# Altium schematic sheet Analog2.SchDoc
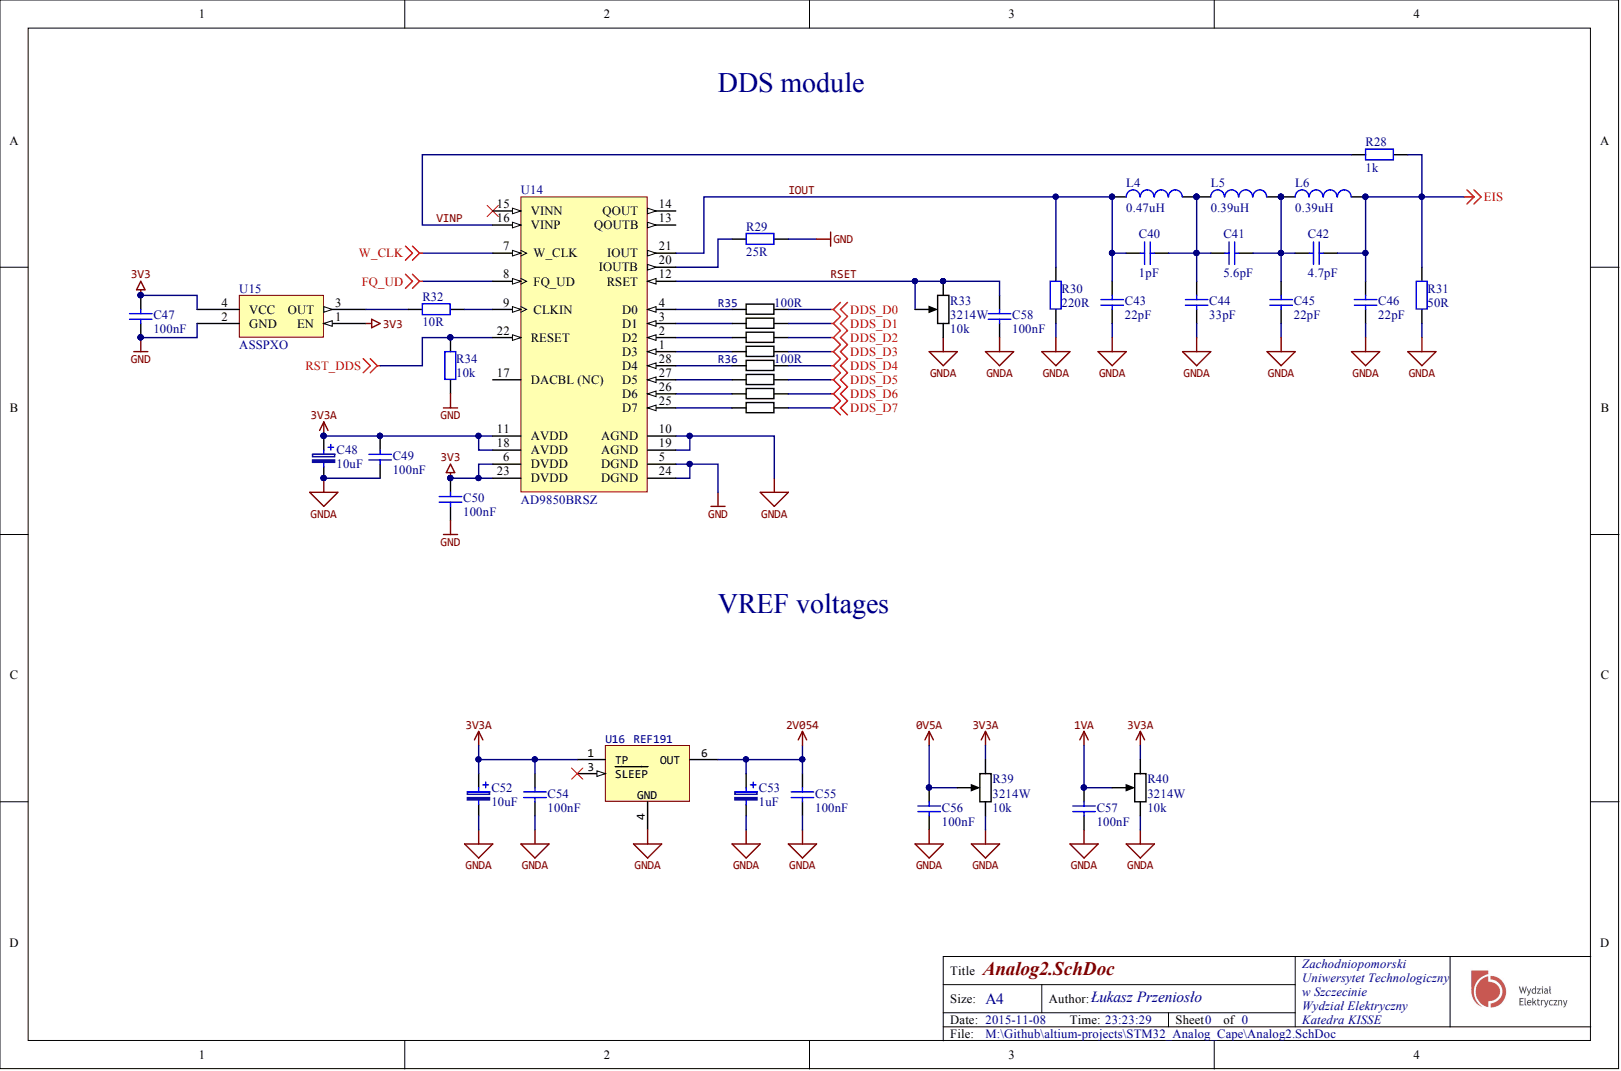
[diagram p0: Analog2.SchDoc]

## Parts
C40  1pF  [CAPC1608N]
C41  5.6pF  [CAPC1608N]
C42  4.7pF  [CAPC1608N]
C43  22pF  [CAPC1608N]
C44  33pF  [CAPC1608N]
C45  22pF  [CAPC1608N]
C46  22pF  [CAPC1608N]
C47  100nF  [CAPC1608N]
C48  10uF  [SMDA]
C49  100nF  [CAPC1608N]
C50  100nF  [CAPC1608N]
C52  10uF  [SMDA]
C53  1uF  [SMDA]
C54  100nF  [CAPC1608N]
C55  100nF  [CAPC1608N]
C56  100nF  [CAPC1608N]
C57  100nF  [CAPC1608N]
C58  100nF  [CAPC1608N]
L4  value 0.47uH  [inductor_0603]  (hidden comment: Ferrite)
L5  value 0.39uH  [inductor_0603]  (hidden comment: Ferrite)
L6  value 0.39uH  [inductor_0603]  (hidden comment: Ferrite)
R28  1k  [RESC1608N]
R29  25R  [RESC1608N]
R30  220R  [RESC1608N]
R31  50R  [RESC1608N]
R32  10R  [RESC1608N]
R33  3214W  value 10k  [POT3214W]
R34  10k  [RESC1608N]
R35  value 100R  [RES_ARRAY_4x0603]  (hidden comment: RES_ARRAY_4)
R36  value 100R  [RES_ARRAY_4x0603]  (hidden comment: RES_ARRAY_4)
R39  3214W  value 10k  [POT3214W]
R40  3214W  value 10k  [POT3214W]
U14  AD9850BRSZ  [SSOP28]
U15  ASSPXO  [EPON_XO]
U16  REF191  [SO-8_L]

## Nets
0V5A: C56.1 R39.2
1VA: C57.1 R40.2
2V054: C53.1 C55.1 U16.6(OUT)
3V3: C47.1 C50.1 U14.6(DVDD) U14.23(DVDD) U15.1(EN) U15.4(VCC)
3V3A: C48.1 C49.1 C52.1 C54.1 R39.3 R40.1 U14.11(AVDD) U14.18(AVDD) U16.1(TP)
DDS_D0: R35.7
DDS_D1: R35.5
DDS_D2: R35.3
DDS_D3: R35.1
DDS_D4: R36.7
DDS_D5: R36.5
DDS_D6: R36.3
DDS_D7: R36.1
EIS: C42.2 C46.2 L6.2 R28.2 R31.1
FQ_UD: U14.8(FQ_UD)
GND: C47.2 C50.2 R29.1 R34.2 U14.5(DGND) U14.24(DGND) U15.2(GND)
GNDA: C43.1 C44.1 C45.1 C46.1 C48.2 C49.2 C52.2 C53.2 C54.2 C55.2 C56.2 C57.2 C58.1 R30.2 R31.2 R33.3 R39.1 R40.3 U14.10(AGND) U14.19(AGND) U16.4
RST_DDS: R34.1 U14.22(RESET)
W_CLK: U14.7(W_CLK)
IOUT: C40.1 C43.2 L4.1 R30.1 U14.21(IOUT)
RSET: C58.2 R33.1 R33.2 U14.12(RSET)
VINP: R28.1 U14.16(VINP)
NetC40_2: C40.2 C41.1 C44.2 L4.2 L5.1
NetC41_2: C41.2 C42.1 C45.2 L5.2 L6.1
NetR29_2: R29.2 U14.20(IOUTB)
NetR32_1: R32.1 U14.9(CLKIN)
NetR32_2: R32.2 U15.3(OUT)
NetR35_2: R35.2 U14.1(D3)
NetR35_4: R35.4 U14.2(D2)
NetR35_6: R35.6 U14.3(D1)
NetR35_8: R35.8 U14.4(D0)
NetR36_2: R36.2 U14.25(D7)
NetR36_4: R36.4 U14.26(D6)
NetR36_6: R36.6 U14.27(D5)
NetR36_8: R36.8 U14.28(D4)

Not connected: U14 13(QOUTB) 14(QOUT) 15(VINN) 17; U16 3(SLEEP)

## Library data of the parts
C40-C47 C49 C50 C54-C58 = Capacitor: Component Kind: Standard; Description: Capacitor; Library Name: Discrete.SchLib; Signal Integrity: Cap; Simulation: CAP
  C40: Value: 1pF
  C41: Value: 5.6pF
  C42: Value: 4.7pF
  C43 C45 C46: Value: 22pF
  C44: Value: 33pF
  C47 C49 C50 C54-C58: Value: 100nF
C48 C52 = 100uF 6.3V-SMDB: Polarized Capacitor (Surface Mount); Component Kind: Standard; Distributor: tme.pl; Distributor Order Code: TC 100/6.3-SMDB; farnell: 1135048; PackageReference: SMDB; Signal Integrity: Cap Pol; Simulation: CAP; tme.pl: TC 100/6.3-SMDB; Value: 10uF; Library Name: Mechatronic.SchLib
C53 = 100uF 6.3V-SMDB: Polarized Capacitor (Surface Mount); Value: 10uF; Component Kind: Standard; Distributor: tme.pl; Distributor Order Code: TC 100/6.3-SMDB; farnell: 1135048; PackageReference: SMDB; Signal Integrity: Cap Pol; Simulation: CAP; tme.pl: TC 100/6.3-SMDB; Library Name: Mechatronic.SchLib
L4-L6 = Inductor: Code_IEC: 1005; Code_JEITA: 0402; Component Kind: Standard; Description: Inductor; LatestRevisionDate: 29-May-2009; LatestRevisionNote: IPC-7351 Footprint Added.; Library Name: Miscellaneous Devices.IntLib; PackageDescription: Chip Inductor; PackageReference: 0402-A; Published: 8-Jun-2000; Signal Integrity: Inductor; Simulation: INDUCTOR; Publisher: Altium Limited
R28-R31 R34 = Resistor: Power: 0W; Tolerance: 1%; Component Kind: Standard; Description: Resistor; Library Name: Discrete.SchLib; Signal Integrity: Res; Simulation: RESISTOR
  R28: Value: 1k
  R29: Value: 25R
  R30: Value: 220R
  R31: Value: 50R
  R34: Value: 10k
R32 = Resistor: Power: 0W; Tolerance: 5%; Component Kind: Standard; Description: Resistor; Library Name: Discrete.SchLib; Signal Integrity: Res; Simulation: RESISTOR; Value: 10R
R33 R39 R40 = RPot: Potentiometer; Set Position: 0.5; Sim Note: Enter the Resistance value and the set point into parameter fields.; Component Kind: Standard; LatestRevisionDate: 17-Jul-2002; LatestRevisionNote: Re-released for DXP Platform.; PackageDescription: Variable Resistor, Thru-Hole; 3 Leads; PackageReference: VR5; Published: 8-Jun-2000; Simulation: POT; Library Name: my_various_devices.SchLib; Publisher: Altium Limited
R35 R36 = RES_ARRAY_1206: Component Kind: Standard; Library Name: my_various_devices.SchLib
U14 = AD9850: CMOS, 125 MHz Complete DDS Synthesizer, 10-Bit, Parallel, Serial, RS-28, 47 Tube, Pb-Free; Compliance Range (V): 1.5V; FS Iout (mA nom): 20mA; I Supply total (max): 96mA; Master fclk: 125MHz; REFCLK Multiplier: No; Resolution (Bits): 10bit; Tuning Word Width (bits): 32bit; Code_JEDEC: MO-150-AH; Component Kind: Standard; ComponentLink1Description: Manufacturer URL; ComponentLink1URL: www.analog.com; ComponentLink2Description: Datasheet; ComponentLink2URL: http://www.analog.com/static/imported-files/data_sheets/AD9850.pdf; ComponentLink3Description: Package Specification; ComponentLink3URL: http://www.analog.com/static/imported-files/packages/32122249223724RS_28.pdf; DatasheetVersion: Rev. H; I/O Interface: Parallel, Serial; PackageDescription: 28-Lead Shrink Small Outline Package [SSOP]; PackageReference: RS-28; PackageVersion: revA, 1997; Library Name: my_various_devices.SchLib
U15 = CFPS-39: Component Kind: Standard; Signal Integrity: ECS-3M; Library Name: my_various_devices.SchLib
U16 = REF191: REF191 2.048V; Component Kind: Standard; Library Name: my_various_devices.SchLib
